# Altium schematic sheet Schematic.SchDoc(Schematic)
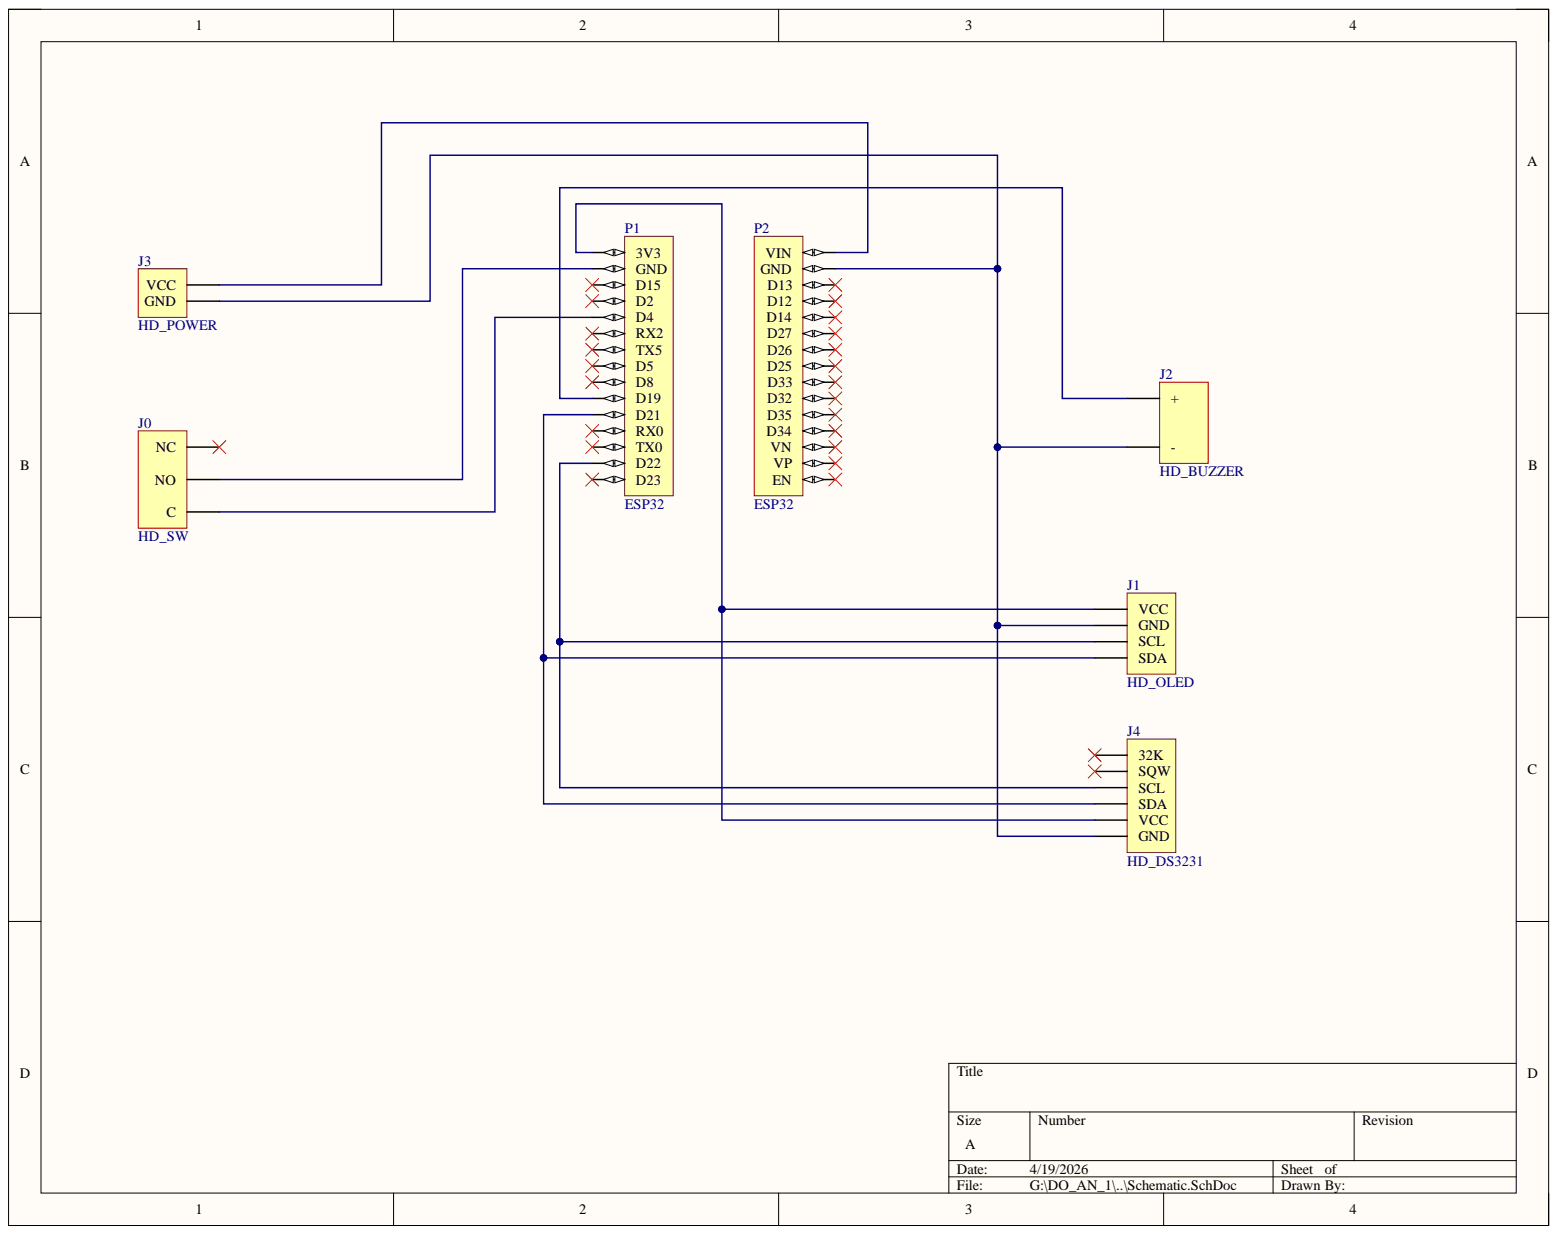
[diagram p0: Schematic.SchDoc]

## Parts
J0  HD_SW  [HDR1X5]
J1  HD_OLED  [HDR1X4]
J2  HD_BUZZER  [HDR1X4]
J3  HD_POWER  [HDR1X2]
J4  HD_DS3231  [HDR1X6]
P1  ESP32  [HDR1X15]
P2  ESP32  [HDR1X15]

## Nets
NetJ0_3: J0.3 P1.2(GND)
NetJ0_5: J0.5 P1.5(D4)
NetJ1_1: J1.1(VCC) J4.5(VCC) P1.1(3V3)
NetJ1_2: J1.2(GND) J2.4 J3.2 J4.6(GND) P2.14(GND)
NetJ1_3: J1.3(SCL) J4.3(SCL) P1.14(D22)
NetJ1_4: J1.4(SDA) J4.4(SDA) P1.11(D21)
NetJ2_1: J2.1 P1.10(D19)
NetJ3_1: J3.1 P2.15(VIN)

Not connected: J0 1; J4 1(32K) 2(SQW); P1 3(D15) 4(D2) 6(RX2) 7(TX5) 8(D5) 9(D8) 12(RX0) 13(TX0) 15(D23); P2 1(EN) 2(VP) 3(VN) 4(D34) 5(D35) 6(D32) 7(D33) 8(D25) 9(D26) 10(D27) 11(D14) 12(D12) 13(D13)

## Library data of the parts
J0 = Header 5: Header, 5-Pin; Component Kind: Standard; LatestRevisionDate: 17-Jul-2002; LatestRevisionNote: Re-released for DXP Platform.; Library Name: Miscellaneous Connectors.IntLib; Signal Integrity: Connector; Publisher: Altium Limited
J1 J2 = Header 4: Header, 4-Pin; Component Kind: Standard; LatestRevisionDate: 17-Jul-2002; LatestRevisionNote: Re-released for DXP Platform.; Library Name: Miscellaneous Connectors.IntLib; Signal Integrity: Connector; Publisher: Altium Limited
J3 = Header 2: Header, 2-Pin; Component Kind: Standard; LatestRevisionDate: 17-Jul-2002; LatestRevisionNote: Re-released for DXP Platform.; Library Name: Miscellaneous Connectors.IntLib; Signal Integrity: Connector; Publisher: Altium Limited
J4 = Header 6: Header, 6-Pin; Component Kind: Standard; LatestRevisionDate: 17-Jul-2002; LatestRevisionNote: Re-released for DXP Platform.; Library Name: Miscellaneous Connectors.IntLib; Signal Integrity: Connector; Publisher: Altium Limited
P1 P2 = Header 15: Header, 15-Pin; Component Kind: Standard; LatestRevisionDate: 17-Jul-2002; LatestRevisionNote: Re-released for DXP Platform.; Library Name: Miscellaneous Connectors.IntLib; Signal Integrity: Connector; Publisher: Altium Limited
